# Altium schematic sheet RGB_LEDs.SchDoc(RGB_LEDs)
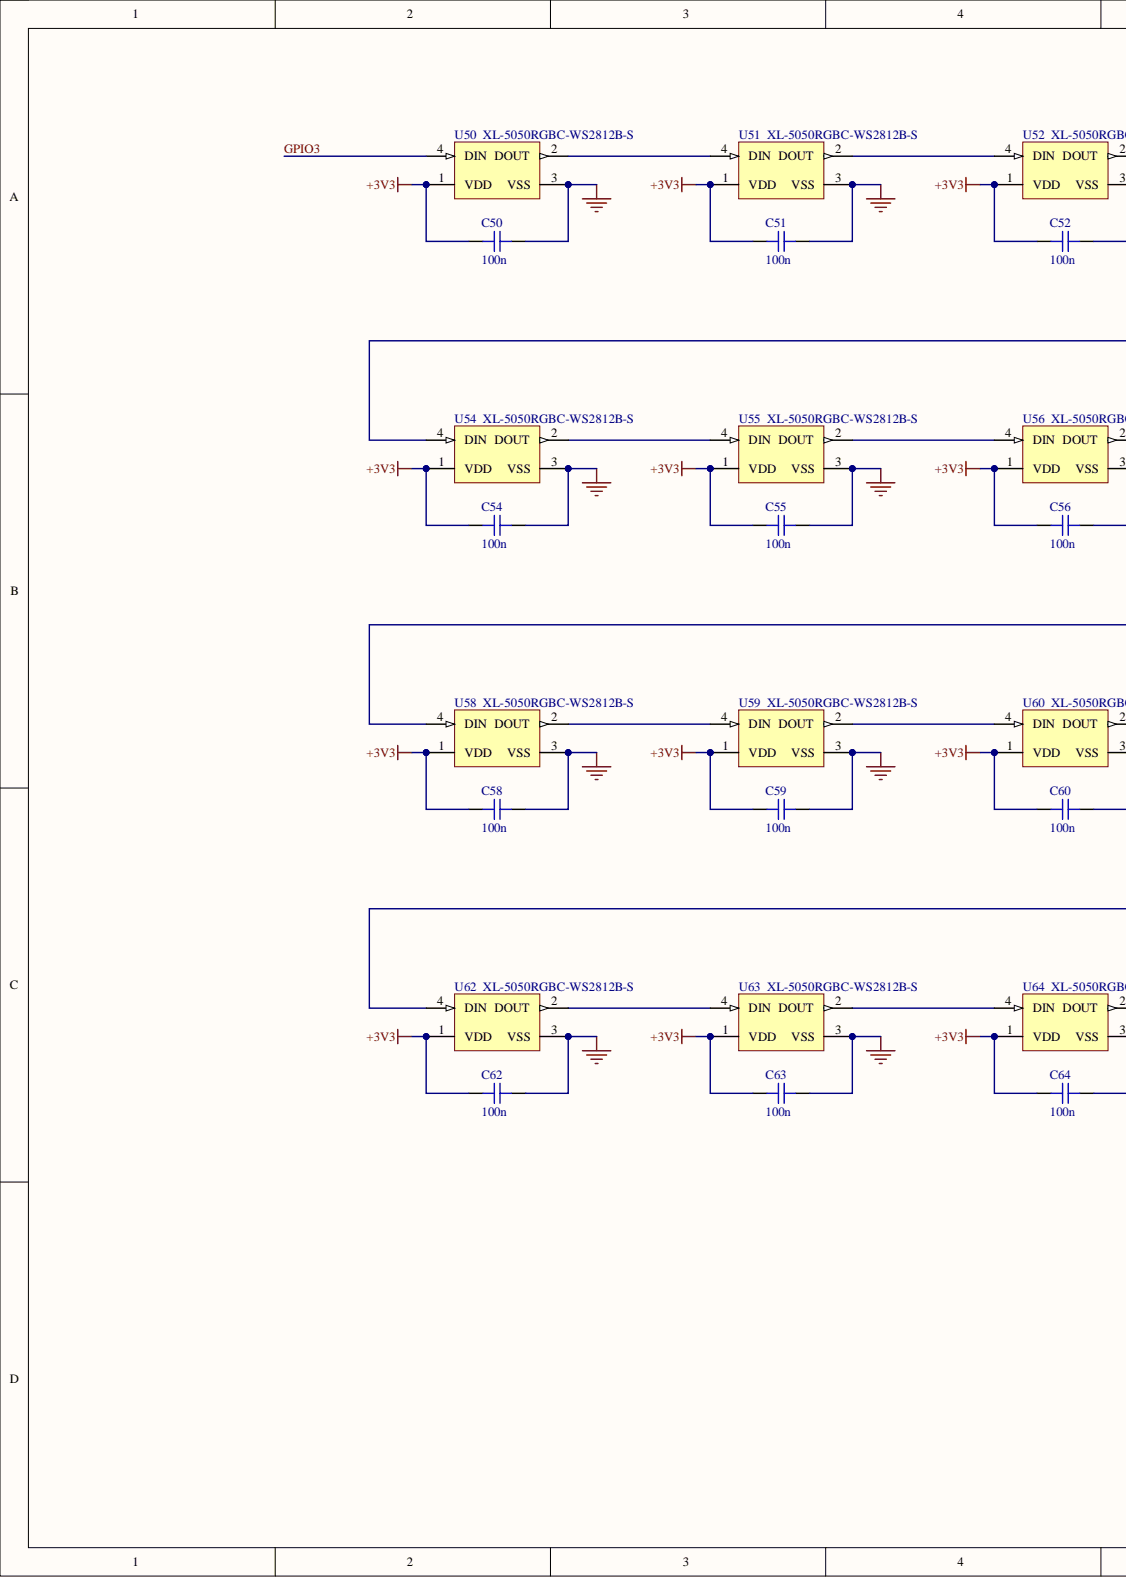
[diagram p0: RGB_LEDs.SchDoc, left]
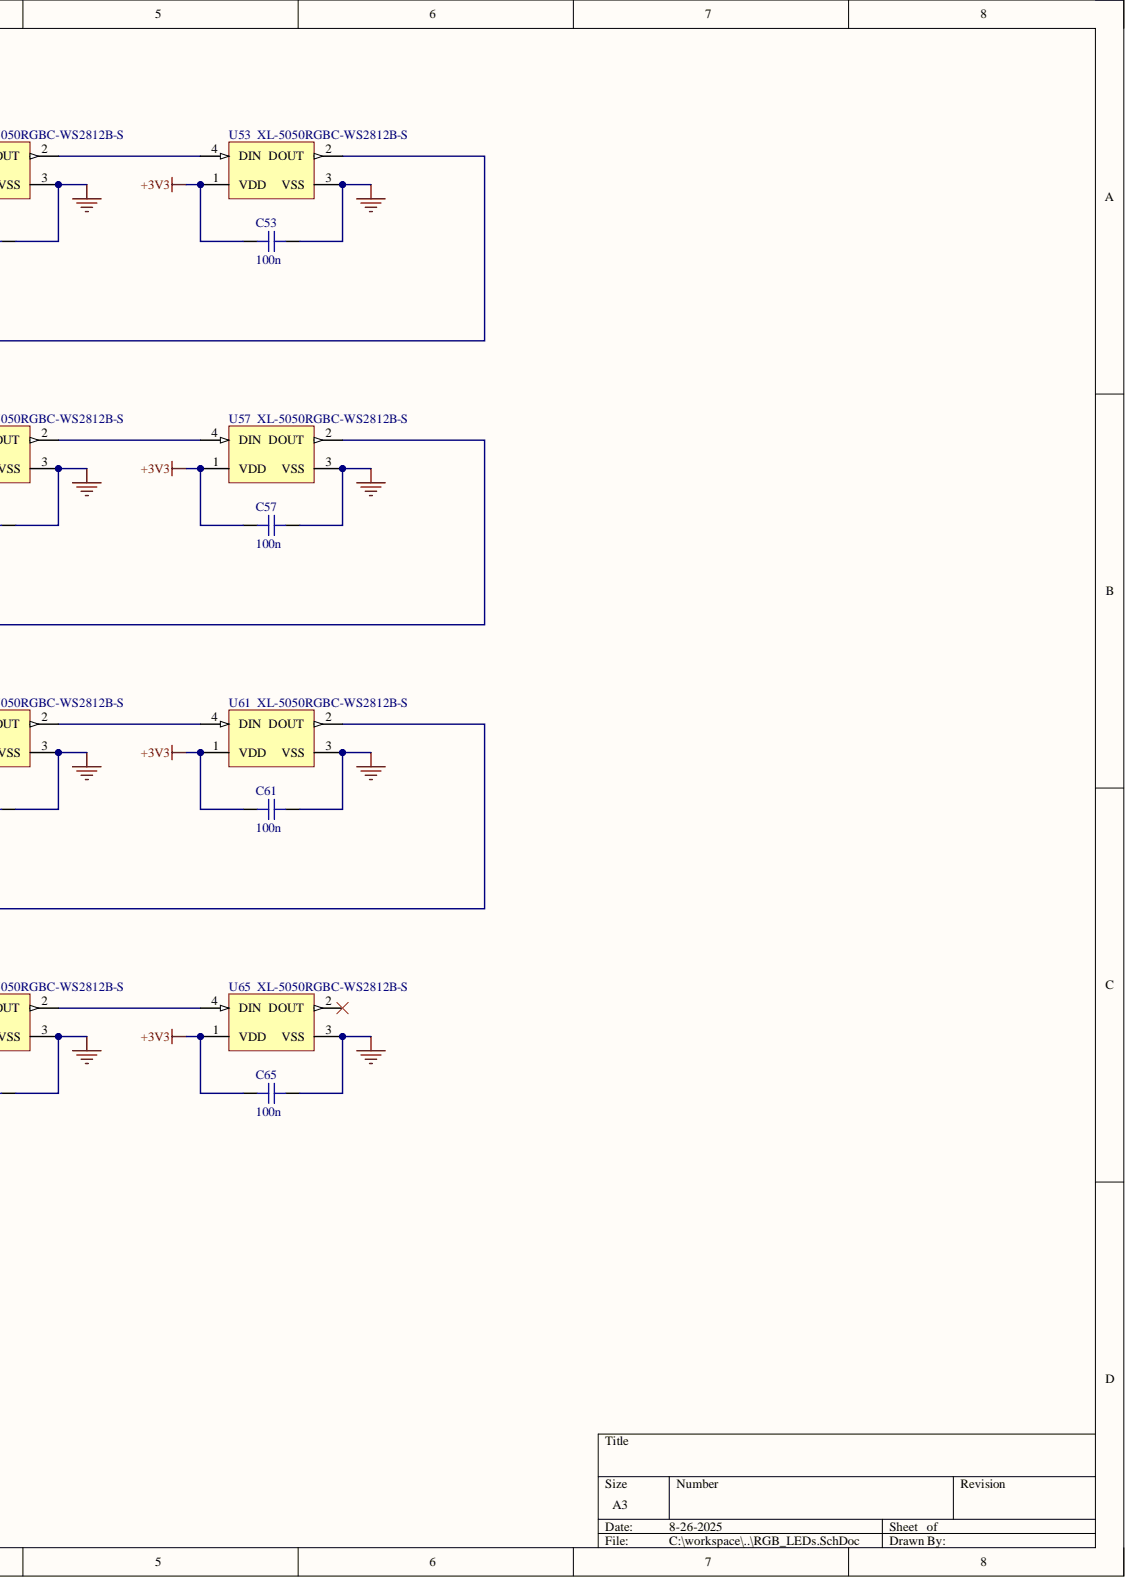
[diagram p1: RGB_LEDs.SchDoc, right]

## Parts
C50  100n  [CAP_0402]
C51  100n  [CAP_0402]
C52  100n  [CAP_0402]
C53  100n  [CAP_0402]
C54  100n  [CAP_0402]
C55  100n  [CAP_0402]
C56  100n  [CAP_0402]
C57  100n  [CAP_0402]
C58  100n  [CAP_0402]
C59  100n  [CAP_0402]
C60  100n  [CAP_0402]
C61  100n  [CAP_0402]
C62  100n  [CAP_0402]
C63  100n  [CAP_0402]
C64  100n  [CAP_0402]
C65  100n  [CAP_0402]
U50  XL-5050RGBC-WS2812B-S  [ADA-RGB-1655_V]
U51  XL-5050RGBC-WS2812B-S  [ADA-RGB-1655_V]
U52  XL-5050RGBC-WS2812B-S  [ADA-RGB-1655_V]
U53  XL-5050RGBC-WS2812B-S  [ADA-RGB-1655_V]
U54  XL-5050RGBC-WS2812B-S  [ADA-RGB-1655_V]
U55  XL-5050RGBC-WS2812B-S  [ADA-RGB-1655_V]
U56  XL-5050RGBC-WS2812B-S  [ADA-RGB-1655_V]
U57  XL-5050RGBC-WS2812B-S  [ADA-RGB-1655_V]
U58  XL-5050RGBC-WS2812B-S  [ADA-RGB-1655_V]
U59  XL-5050RGBC-WS2812B-S  [ADA-RGB-1655_V]
U60  XL-5050RGBC-WS2812B-S  [ADA-RGB-1655_V]
U61  XL-5050RGBC-WS2812B-S  [ADA-RGB-1655_V]
U62  XL-5050RGBC-WS2812B-S  [ADA-RGB-1655_V]
U63  XL-5050RGBC-WS2812B-S  [ADA-RGB-1655_V]
U64  XL-5050RGBC-WS2812B-S  [ADA-RGB-1655_V]
U65  XL-5050RGBC-WS2812B-S  [ADA-RGB-1655_V]

## Nets
+3V3: C50.2 C51.2 C52.2 C53.2 C54.2 C55.2 C56.2 C57.2 C58.2 C59.2 C60.2 C61.2 C62.2 C63.2 C64.2 C65.2 U50.1(VDD) U51.1(VDD) U52.1(VDD) U53.1(VDD) U54.1(VDD) U55.1(VDD) U56.1(VDD) U57.1(VDD) U58.1(VDD) U59.1(VDD) U60.1(VDD) U61.1(VDD) U62.1(VDD) U63.1(VDD) U64.1(VDD) U65.1(VDD)
GND: C50.1 C51.1 C52.1 C53.1 C54.1 C55.1 C56.1 C57.1 C58.1 C59.1 C60.1 C61.1 C62.1 C63.1 C64.1 C65.1 U50.3(VSS) U51.3(VSS) U52.3(VSS) U53.3(VSS) U54.3(VSS) U55.3(VSS) U56.3(VSS) U57.3(VSS) U58.3(VSS) U59.3(VSS) U60.3(VSS) U61.3(VSS) U62.3(VSS) U63.3(VSS) U64.3(VSS) U65.3(VSS)
GPIO3: U50.4(DIN)
NetU50_2: U50.2(DOUT) U51.4(DIN)
NetU51_2: U51.2(DOUT) U52.4(DIN)
NetU52_2: U52.2(DOUT) U53.4(DIN)
NetU53_2: U53.2(DOUT) U54.4(DIN)
NetU54_2: U54.2(DOUT) U55.4(DIN)
NetU55_2: U55.2(DOUT) U56.4(DIN)
NetU56_2: U56.2(DOUT) U57.4(DIN)
NetU57_2: U57.2(DOUT) U58.4(DIN)
NetU58_2: U58.2(DOUT) U59.4(DIN)
NetU59_2: U59.2(DOUT) U60.4(DIN)
NetU60_2: U60.2(DOUT) U61.4(DIN)
NetU61_2: U61.2(DOUT) U62.4(DIN)
NetU62_2: U62.2(DOUT) U63.4(DIN)
NetU63_2: U63.2(DOUT) U64.4(DIN)
NetU64_2: U64.2(DOUT) U65.4(DIN)

Not connected: U65 2(DOUT)

## Library data of the parts
C50-C65 = CAP_0402_50V: Capacitor SMD 0402 50V; Manufacturer: Samsung; Manufacturer Part Number: CL05B104KO5NNNC; Component Kind: Standard; LCSC: C1525; Library Name: BSides_2024.IntLib
U50-U65 = XL-5050RGBC-WS2812B-S: Addressable RGB LED 5x5mm; Component Kind: Standard; LCSC: C22461793; Library Name: BSides_2024.SCHLIB
